# Altium schematic sheet High Voltage Section.SchDoc("High Voltage Section")
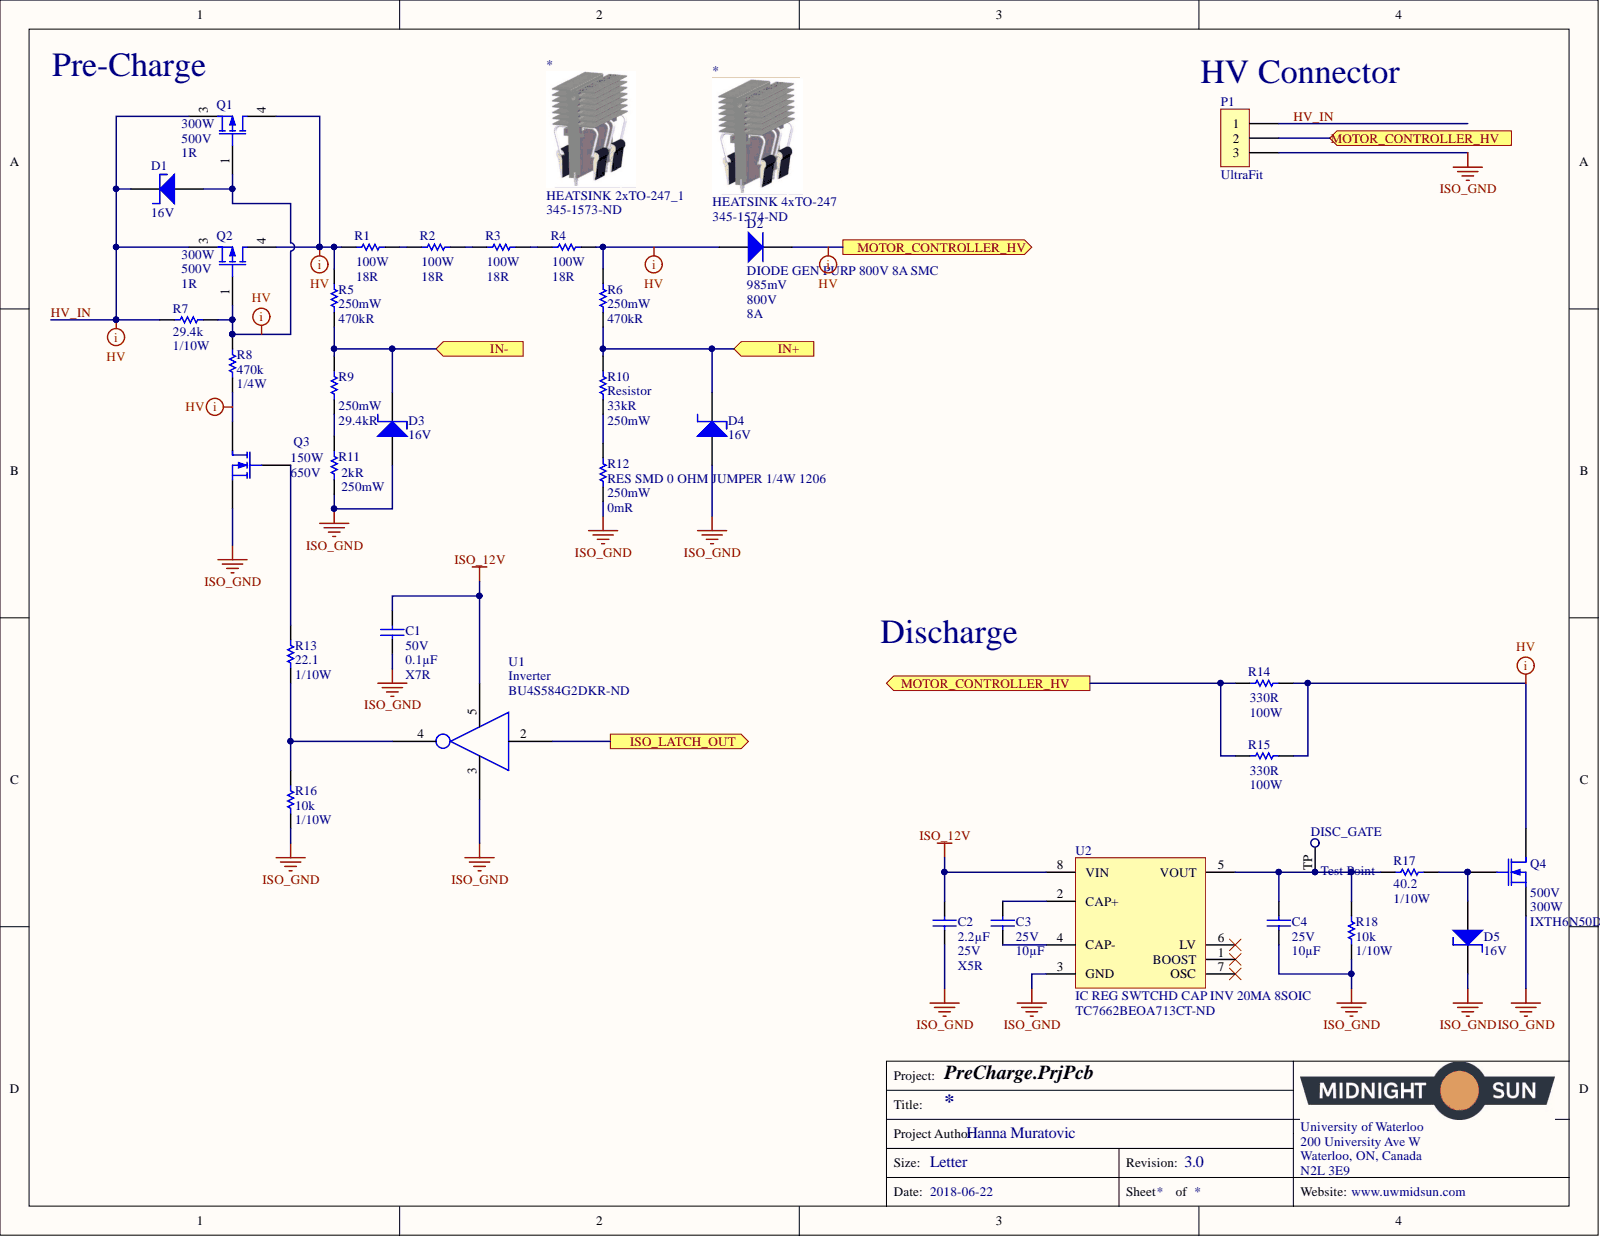
[diagram p0: High Voltage Section.SchDoc]

## Parts
*  HEATSINK 4xTO-247  [HEATSINK 4x TO-247]
*  HEATSINK 2xTO-247_1  [HEATSINK 2x TO-247]
C1  Voltage: 50V  Capacitance: 0.1µF  [CAP, 0603]  (hidden comment: CAP CER 0.1UF 50V 10% X7R 0603)
C2  Voltage: 25V  Temperature Coefficient: X5R  Capacitance: 2.2µF  [CAP, 0603]  (hidden comment: CAP CER 2.2UF 25V 10% X5R 0603)
C3  Voltage - Rated: 25V  Capacitance: 10µF  [CAP, 0805]  (hidden comment: CAP CER 10uF 25V 10% X5R 0805)
C4  Voltage - Rated: 25V  Capacitance: 10µF  [CAP, 0805]  (hidden comment: CAP CER 10uF 25V 10% X5R 0805)
D1  Voltage - Zener (Nom): 16V  [DO-214AA Diode]  (hidden comment: DIODE ZENER 16V 5W DO-214AA (SMB))
D2  DIODE GEN PURP 800V 8A SMC  Forward Voltage: 985mV  [DIODE, SMC]
D3  Voltage - Zener (Nom): 16V  [DO-214AA Diode]  (hidden comment: DIODE ZENER 16V 5W DO-214AA (SMB))
D4  Voltage - Zener (Nom): 16V  [DO-214AA Diode]  (hidden comment: DIODE ZENER 16V 5W DO-214AA (SMB))
D5  Voltage - Zener (Nom): 16V  [DO-214AA Diode]  (hidden comment: DIODE ZENER 16V 5W DO-214AA (SMB))
DISC_GATE  Test Point  [Test Point]  (no BOM)
P1  Series: UltraFit  (hidden comment: CONN 3POS ULTRA-FIT 0.138")
Q1  Rds On Max: 1R  Power Dissipation: 300W  Drain to Source Voltage (Vdss): 500V  [P-CH MOSFET 500V 10A 300W TO-263]  (hidden comment: IXTA10P50P)
Q2  Rds On Max: 1R  Power Dissipation: 300W  Drain to Source Voltage (Vdss): 500V  [P-CH MOSFET 500V 10A 300W TO-263]  (hidden comment: IXTA10P50P)
Q3  Max Power Dissipation: 150W  Drain to Source Voltage (Vdss): 650V  [P-CH MOSFET 500V 10A 300W TO-263]  (hidden comment: MOSFET N-CH 650V 8A X2 TO-263)
Q4  Max Power Dissipation: 300W  Drain to Source Voltage (Vdss): 500V  [N-CH Depletion Mode TO-247-3]  (hidden comment: MOSFET DEPLETION N-CH 500V 6A TO247)
R1  Power Rating: 100W  [RES TO-247-2]  (hidden comment: RES 18 OHM 100W 5% TO-247)
R2  Resistance: 18R  Power Rating: 100W  [RES TO-247-2]  (hidden comment: RES 18 OHM 100W 5% TO-247)
R3  Resistance: 18R  Power Rating: 100W  [RES TO-247-2]  (hidden comment: RES 18 OHM 100W 5% TO-247)
R4  Resistance: 18R  Power Rating: 100W  [RES TO-247-2]  (hidden comment: RES 18 OHM 100W 5% TO-247)
R5  Resistance: 470kR  Max Power Dissipation: 250mW  [RES 1206]  (hidden comment: RESISTOR)
R6  Resistance: 470kR  Max Power Dissipation: 250mW  [RES 1206]  (hidden comment: RESISTOR)
R7  Resistance (Ohms): 29.4k  Power (Watts): 1/10W  [RES, 0603]  (hidden comment: RES 29.4K OHM 1% 1/10W 0603)
R8  Resistance: 470k  Power: 1/4W  [RES, 0603]  (hidden comment: RES 470K OHM 1% 1/4W 0603)
R9  Resistor  Resistance: 29.4kR  Power Rating: 250mW  [RES 1206]
R10  Resistor  Resistance: 33kR  Power Rating: 250mW  [RES 1206]
R11  Resistance: 2kR  Power Rating: 250mW  [RES 1206]  (hidden comment: RES SMD 2K OHM 0.1% 1/4W 1206)
R12  RES SMD 0 OHM JUMPER 1/4W 1206  Resistance: 0mR  Power Rating: 250mW  [RES 1206]
R13  Resistance: 22.1  Power: 1/10W  [RES, 0603]  (hidden comment: RES 22.1 OHM 1% 1/10W 0603)
R14  Resistance: 330R  Power Rating: 100W  [RES TO-247-2]  (hidden comment: RES 330 OHM 100W 5% TO-247)
R15  Resistance: 330R  Power Rating: 100W  [RES TO-247-2]  (hidden comment: RES 330 OHM 100W 5% TO-247)
R16  Resistance: 10k  Power: 1/10W  [RES, 0603]  (hidden comment: RES 10K OHM 1% 1/10W 0603)
R17  Resistance: 40.2  Power: 1/10W  [RES, 0603]  (hidden comment: RES 40.2 OHM 0.5% 1/10W 0603)
R18  Resistance: 10k  Power: 1/10W  [RES, 0603]  (hidden comment: RES 10K OHM 1% 1/10W 0603)
U1  Inverter  Supplier Part Number 1: BU4S584G2DKR-ND  [SSOP5 - Inverter]
U2  IC REG SWTCHD CAP INV 20MA 8SOIC  Supplier Part Number 1: TC7662BEOA713CT-ND  [SOIC8]

## Ports
IN+, IN-, ISO_LATCH_OUT, MOTOR_CONTROLLER_HV

## Nets
ISO_12V: C1.1 C2.2 U1.5 U2.8(VIN)
ISO_GND: C1.2 C2.1 C4.2 D3.1 D4.1 D5.2 P1.3 Q3.3 Q4.3 R11.2 R12.2 R16.2 R18.2 U1.3 U2.3(GND)
HV_IN: D1.2 P1.1 Q1.3 Q2.3 R7.2
ISO_LATCH_OUT: U1.2 ; port ISO_LATCH_OUT
MOTOR_CONTROLLER_HV: D2.1 P1.2 R14.1 R15.1 ; port MOTOR_CONTROLLER_HV
NetC3_1: C3.1 U2.2(CAP+)
NetC3_2: C3.2 U2.4(CAP-)
NetC4_1: C4.1 DISC_GATE.TP R17.1 R18.1 U2.5(VOUT)
NetD1_1: D1.1 Q1.1 Q2.1 R7.1 R8.1
NetD2_2: D2.2 R4.2 R6.1
NetD3_2: D3.2 R5.2 R9.1
NetD4_2: D4.2 R6.2 R10.1
NetD5_1: D5.1 Q4.1 R17.2
NetQ1_4: Q1.4 Q2.4 R1.1 R5.1
NetQ3_1: Q3.1 R13.1
NetQ3_4: Q3.4 R8.2
NetQ4_2: Q4.2 R14.2 R15.2
NetR1_2: R1.2 R2.1
NetR2_2: R2.2 R3.1
NetR3_2: R3.2 R4.1
NetR9_2: R9.2 R11.1
NetR10_2: R10.2 R12.1
NetR13_2: R13.2 R16.1 U1.4

Not connected: U2 1(BOOST) 6(LV) 7(OSC)

## Library data of the parts
* = HEATSINK 4xTO-247: n Heat Sink TO-220, TO-247, TO-264 Aluminum Board Level, Vertical; Manufacturer Part Number: OMNI-UNI-30-50-D; Manufacturer: Wakefield; Supplier Part Number 1: 345-1574-ND; Supplier 1: Digi-Key; Stock 1: 465; Pricing 1: 1=4.09, 10=3.98, 25=3.8724, 50=3.6572, 100=3.4421, 250=3.22696, 500=3.11938, 1000=2.79669 (USD); Component Kind: Standard; Library Name: Schematic Diagrams.SchLib
* = HEATSINK 2xTO-247_1: Heat Sink TO-220, TO-247, TO-264 Aluminum Board Level, Vertical; Manufacturer Part Number: OMNI-UNI-30-50-D; Manufacturer: Wakefield; Supplier Part Number 1: 345-1573-ND; Supplier 1: Digi-Key; Stock 1: 499; Pricing 1: 1=2.8, 10=2.728, 25=2.6544, 50=2.5068, 100=2.3594, 250=2.21192, 500=2.13818, 1000=1.91698, 5000=1.88012 (USD); Component Kind: Standard; Library Name: Schematic Diagrams.SchLib
C1 = CAP CER 0.1UF 50V 10% X7R 0603: 0.10µF ±10% 50V Ceramic Capacitor X7R 0603 (1608 Metric); Manufacturer Part Number 1: 06035C104KAT2A; Manufacturer 1: AVX Corporation; Tolerance: ±10%; Thickness (Max): 0.035" (0.90mm); Temperature Coefficient: X7R; Supplier Part Number 1: 478-5052-1-ND; Supplier 1: Digi-Key; Size / Dimension: 0.063" L x 0.032" W (1.60mm x 0.81mm); Ratings: -; Part Status: Active; Packaging: Cut Tape (CT); Package / Case: 0603 (1608 Metric); Operating Temperature: -55°C ~ 125°C; Mounting Type: Surface Mount, MLCC; Lead Style: -; Lead Spacing: -; Height - Seated (Max): -; ComponentLink1URL: http://datasheets.avx.com/X7RDielectric.pdf; ComponentLink1Description: http://datasheets.avx.com/X7RDielectric.pdf; Component Kind: Standard; Library Name: Schematic Diagrams.SchLib
C2 = CAP CER 2.2UF 25V 10% X5R 0603: 2.2µF ±10% 25V Ceramic Capacitor X5R 0603 (1608 Metric); Manufacturer Part Number 1: GRM188R61E225KA12D; Manufacturer 1: Murata Electronics North America; Tolerance: ±10%; Thickness (Max): 0.035" (0.90mm); Supplier Part Number 1: 490-10731-1-ND; Supplier 1: Digi-Key; Size / Dimension: 0.063" L x 0.031" W (1.60mm x 0.80mm); Ratings: -; Part Status: Active; Packaging: Cut Tape (CT); Package / Case: 0603 (1608 Metric); Operating Temperature: -55°C ~ 85°C; Mounting Type: Surface Mount, MLCC; Lead Style: -; Lead Spacing: -; Height - Seated (Max): -; ComponentLink1URL: http://www.murata.com/~/media/webrenewal/support/library/catalog/products/capacitor/mlcc/c02e.ashx; ComponentLink1Description: http://www.murata.com/~/media/webrenewal/support/library/catalog/products/capacitor/mlcc/c02e.ashx; Component Kind: Standard; Library Name: Schematic Diagrams.SchLib
C3 C4 = CAP CER 10uF 25V 10% X5R 0805: 10µF ±10% 25V Ceramic Capacitor X5R 0805 (2012 Metric); Manufacturer Part Number: GRM21BR61E106KA73L; Manufacturer: Murata Electronics North America; Tolerance: ±10%; Thickness (Max): 0.053" (1.35mm); Temperature Coefficient: X5R; Supplier Part Number 1: 490-5523-1-ND; Supplier 1: Digi-Key; Stock 1: 1695157; Size / Dimension: 0.079" L x 0.049" W (2.00mm x 1.25mm); RoHS: RoHS Compliant; Ratings: -; Pricing 1: 1=0.3, 10=0.214, 100=0.1008, 500=0.07068, 1000=0.05851 (CAD); Part Status: Active; Packaging: Cut Tape (CT); Package / Case: 0805 (2012 Metric); Operating Temperature: -55°C ~ 85°C; Mounting Type: Surface Mount, MLCC; Lead Style: -; Lead Spacing: -; Height - Seated (Max): -; Features: -; ComponentLink1URL: http://search.murata.co.jp/Ceramy/image/img/A01X/G101/ENG/GRM21BR61E106KA73-01.pdf; ComponentLink1Description: http://search.murata.co.jp/Ceramy/image/img/A01X/G101/ENG/GRM21BR61E106KA73-01.pdf; Component Kind: Standard; Category: Capacitors; Applications: General Purpose; Library Name: Schematic Diagrams.SchLib
D1 D3-D5 = DIODE ZENER 16V 5W DO-214AA (SMB): Zener Diode 16V 5W ±5% Surface Mount DO-214AA (SMB); Manufacture Part Number: SMBJ5353B-TP; Manufacture: Micro Commercial Co; Voltage - Forward: 1.2V @ 1A; Impedence (Max): 2.5 Ohm; Supplier Part Number 1: SMBJ5353B-TPMSCT-ND; Supplier 1: Digi-Key; Power (Max): 5W; Component Kind: Standard; Library Name: Schematic Diagrams.SchLib
D2 = DIODE GEN PURP 800V 8A SMC: Manufacturer Part Number: S8KC-13; Manufacturer: Diodes; Peak Reverse Current: 10uA; Peak Non-Repetitive Surge Current: 200A; Element Configuration: Single; Width: 6.22mm; Weight: 0.007408oz; Supplier Part Number 1: S8KCDICT-ND; Supplier 1: Digi-Key; Stock 1: 38298; Reverse Voltage (DC): 800V; Radiation Hardening: No; Pricing 1: 1=0.68, 10=0.598, 100=0.4586, 500=0.36256, 1000=0.29005 (USD); Packaging: Tape and Reel; Package Quantity: 3000; Number of Pins: 2; Mount: Surface Mount; Min Operating Temperature: -65°C; Max Surge Current: 200A; Max Reverse Voltage (DC): 800V; Max Repetitive Reverse Voltage (Vrrm): 800V; Max Operating Temperature: 150°C; Max Forward Surge Current (Ifsm): 200A; Length: 7.11mm; Lead Free: Lead Free; Height: 2.42mm; Forward Current: 8A; Contact Plating: Tin,Matte; ComponentLink4URL: https://datasheet.ciiva.com/4453/ds31117-63855-4453947.pdf; ComponentLink4Description: https://datasheet.ciiva.com/4453/ds31117-63855-4453947.pdf; ComponentLink3URL: https://datasheet.ciiva.com/914/ds31117-914779.pdf; ComponentLink3Description: https://datasheet.ciiva.com/914/ds31117-914779.pdf; ComponentLink2URL: https://datasheet.ciiva.com/16388/ds31117-16388346.pdf; ComponentLink2Description: https://datasheet.ciiva.com/16388/ds31117-16388346.pdf; ComponentLink1URL: https://datasheet.ciiva.com/15144/getdatasheetpartid-61102-15144323.pdf; ComponentLink1Description: https://datasheet.ciiva.com/15144/getdatasheetpartid-61102-15144323.pdf; Component Kind: Standard; Case/Package: SMC; Average Rectified Current: 8A; Library Name: Schematic Diagrams.SchLib
P1 = CONN 3POS ULTRA-FIT 0.138": 3 Positions Header Connector 0.138" (3.50mm) Through Hole Tin; Manufacturer Part Number 1: 1722871103; Manufacturer 1: Molex, LLC; Voltage: 400V; Style: Board to Cable/Wire; Shrouding: Shrouded - 4 Wall; Number of Positions Loaded: All; Number of Positions: 3; Material Flammability Rating: UL94 V-0; Mated Stacking Heights: 26.99mm; Contact Length - Post: 0.126" (3.20mm); Termination: Solder; Supplier Part Number 1: WM11702-ND; Supplier 1: Digi-Key; Row Spacing - Mating: -; Pitch - Mating: 0.138" (3.50mm); Part Status: Active; Packaging: Tray; Overall Contact Length: -; Operating Temperature: -40°C ~ 105°C; Number of Rows: 1; Mounting Type: Through Hole; Insulation Material: Liquid Crystal Polymer (LCP); Insulation Height: 0.465" (11.80mm); Insulation Color: Black; Ingress Protection: -; Fastening Type: Latch Holder; Current Rating: -; Contact Type: Male Pin; Contact Shape: Square; Contact Material: Copper Alloy; Contact Length - Mating: -; Contact Finish Thickness - Post: 60µin (1.52µm); Contact Finish Thickness - Mating: 60µin (1.52µm); Contact Finish - Post: Tin; Contact Finish - Mating: Tin; Connector Type: Header; ComponentLink1URL: http://www.molex.com/pdm_docs/sd/1722871103_sd.pdf; ComponentLink1Description: http://www.molex.com/pdm_docs/sd/1722871103_sd.pdf; Component Kind: Standard; Library Name: Schematic Diagrams.SchLib
Q1 Q2 = MOSFET P-CH 500V 10A 300W TO-263: P-Channel 500V 10A (Tc) 300W (Tc) Surface Mount TO-263 (IXTA); Manufacturer: IXYS; Turn-Off Delay Time: 52ns; Rise Time: 28ns; Number of Elements: 1; Input Capacitance: 2.84nF; Gate to Source Voltage (Vgs): 20V; Fall Time: 44ns; Element Configuration: Single; Drain to Source Breakdown Voltage: -500V; Supplier Part Number 1: IXTA10P50P-ND; Supplier 1: Digi-Key; Stock 1: 2142; Radiation Hardening: No; Pricing 1: 1=5.96, 10=5.319, 100=4.3614, 500=3.53166, 1000=2.9785 (USD); Packaging: Rail/Tube; Package Quantity: 50; On-State Resistance: 1R; Mount: Surface Mount; Min Operating Temperature: -55°C; Max Power Dissipation: 300W; Max Operating Temperature: 150°C; Manufacturer Part Number: IXTA10P50P; Lead Free: Lead Free; Drain to Source Resistance: 1R; Continuous Drain Current (ID): 10A; ComponentLink1URL: https://datasheet.ciiva.com/15203/getdatasheetpartid-371166-15203275.pdf; ComponentLink1Description: https://datasheet.ciiva.com/15203/getdatasheetpartid-371166-15203275.pdf; Component Kind: Standard; Case/Package: TO-263; Library Name: Schematic Diagrams.SchLib
Q3 = MOSFET N-CH 650V 8A X2 TO-263: N-Channel 650V 8A (Tc) 150W (Tc) Surface Mount TO-263; Manufacturer Part Number: IXTA8N65X2; Manufacturer: IXYS; Rds On Max: 500mR; Input Capacitance: 800pF; Supplier Part Number 1: IXTA8N65X2-ND; Supplier 1: Digi-Key; Stock 1: 69; Pricing 1: 1=2.85, 10=2.576, 100=2.07, 500=1.61, 1000=1.334 (USD); Packaging: Rail/Tube; Mount: Surface Mount; Min Operating Temperature: -55°C; Max Operating Temperature: 150°C; Continuous Drain Current (ID): 8A; ComponentLink2URL: http://ixapps.ixys.com/DataSheet/DS100649B(IXTY-TA-TP8N65X2).pdf; ComponentLink2Description: http://ixapps.ixys.com/DataSheet/DS100649B(IXTY-TA-TP8N65X2).pdf; ComponentLink1URL: http://www.mouser.com/ds/2/205/DS100649B(IXTY-TA-TP8N65X2)-1132259.pdf; ComponentLink1Description: http://www.mouser.com/ds/2/205/DS100649B(IXTY-TA-TP8N65X2)-1132259.pdf; Component Kind: Standard; Case/Package: TO-263-3; Library Name: Schematic Diagrams.SchLib
Q4 = MOSFET DEPLETION N-CH 500V 6A TO247: N-Channel 500V 6A (Tc) 300W (Tc) Through Hole TO-247 (IXTH); Manufacturer Part Number: IXTH6N50D2; Manufacturer: IXYS; Rds On Max: 500mR; Input Capacitance: 2.8nF; Supplier Part Number 1: IXTH6N50D2-ND; Supplier 1: Digi-Key; Stock 1: 79; Pricing 1: 1=7.25, 10=6.521, 100=5.3613, 500=4.4919, 1000=3.9123 (USD); Packaging: Rail/Tube; Package Quantity: 30; Mount: Through Hole; Continuous Drain Current (ID): 6A; ComponentLink2URL: https://datasheet.ciiva.com/26790/ixth6n50d2-26790808.pdf; ComponentLink2Description: https://datasheet.ciiva.com/26790/ixth6n50d2-26790808.pdf; ComponentLink1URL: https://datasheet.ciiva.com/949/ds100177bixta-tp-th6n50d2-949628.pdf; ComponentLink1Description: https://datasheet.ciiva.com/949/ds100177bixta-tp-th6n50d2-949628.pdf; Component Kind: Standard; Case/Package: TO-247-3; Library Name: Schematic Diagrams.SchLib
R1 = RES 18 OHM 100W 5% TO-247: 18 Ohms ±5% 100W Through Hole Resistor TO-247-2 Moisture Resistant, Non-Inductive Thick Film; Manufacturer Part Number: AP10118RJ; Manufacturer: Ohmite; Voltage Rating (DC): 700V; Temperature Coefficient: 100ppm/°C; Resistance: 18R; Width: 0.195inch; Voltage Rating: 700V; Tolerance: 5%; Supplier Part Number 1: AP101 18R J-ND; Supplier 1: Digi-Key; Stock 1: 0; REACH SVHC: Unknown; Packaging: Tray; Number of Terminations: 2; Min Operating Temperature: -65°C; Max Power Dissipation: 100W; Max Operating Temperature: 175°C; Length: 0.62inch; Height: 0.825inch; Composition: Thick Film; ComponentLink3URL: http://www.ohmite.com/cat/acl_ap101.pdf; ComponentLink3Description: http://www.ohmite.com/cat/acl_ap101.pdf; ComponentLink2URL: http://www.mouser.com/ds/2/303/acl_ap101-773331.pdf; ComponentLink2Description: http://www.mouser.com/ds/2/303/acl_ap101-773331.pdf; ComponentLink1URL: http://www.farnell.com/datasheets/2015590.pdf; ComponentLink1Description: http://www.farnell.com/datasheets/2015590.pdf; Component Kind: Standard; Case/Package: TO-247-2; Library Name: Schematic Diagrams.SchLib
R2-R4 = RES 18 OHM 100W 5% TO-247: 18 Ohms ±5% 100W Through Hole Resistor TO-247-2 Moisture Resistant, Non-Inductive Thick Film; Manufacturer Part Number: AP10118RJ; Manufacturer: Ohmite; Voltage Rating (DC): 700V; Temperature Coefficient: 100ppm/°C; Width: 0.195inch; Voltage Rating: 700V; Tolerance: 5%; Supplier Part Number 1: AP101 18R J-ND; Supplier 1: Digi-Key; Stock 1: 0; REACH SVHC: Unknown; Packaging: Tray; Number of Terminations: 2; Min Operating Temperature: -65°C; Max Power Dissipation: 100W; Max Operating Temperature: 175°C; Length: 0.62inch; Height: 0.825inch; Composition: Thick Film; ComponentLink3URL: http://www.ohmite.com/cat/acl_ap101.pdf; ComponentLink3Description: http://www.ohmite.com/cat/acl_ap101.pdf; ComponentLink2URL: http://www.mouser.com/ds/2/303/acl_ap101-773331.pdf; ComponentLink2Description: http://www.mouser.com/ds/2/303/acl_ap101-773331.pdf; ComponentLink1URL: http://www.farnell.com/datasheets/2015590.pdf; ComponentLink1Description: http://www.farnell.com/datasheets/2015590.pdf; Component Kind: Standard; Case/Package: TO-247-2; Library Name: Schematic Diagrams.SchLib
R5 R6 = RES SMD 470K OHM 0.1% 1/4W 1206: 470 kOhms ±0.1% 0.25W, 1/4W Chip Resistor 1206 (3216 Metric) Anti-Sulfur, Automotive AEC-Q200 Thin Film; Manufacturer Part Number: RG3216P-4703-B-T1; Manufacturer: Susumu; Voltage Rating (DC): 200V; Voltage Rating: 150V; Temperature Coefficient: 25ppm/°C; Power Rating: 125mW; Width: 0.063inch; Tolerance: 0.1%; Termination: SMD/SMT; Supplier Part Number 1: RG32P470KBDKR-ND; Supplier 1: Digi-Key; Stock 1: 2986; Pricing 1: 1=0.66, 10=0.474, 100=0.2462 (USD); Packaging: Tape and Reel; Package Quantity: 1; Number of Terminations: 2; Number of Pins: 2; Min Operating Temperature: -55°C; Max Operating Temperature: 155°C; Length: 0.126inch; Lead Free: Lead Free; Height: 0.02inch; Composition: Thin Film; ComponentLink4URL: https://datasheet.ciiva.com/12760/rg-rm-rgh-datasheet-12760397.pdf; ComponentLink4Description: https://datasheet.ciiva.com/12760/rg-rm-rgh-datasheet-12760397.pdf; ComponentLink3URL: https://datasheet.ciiva.com/2490/rg-rm-rgh-datasheet-2490490.pdf; ComponentLink3Description: https://datasheet.ciiva.com/2490/rg-rm-rgh-datasheet-2490490.pdf; ComponentLink2URL: https://datasheet.ciiva.com/2490/rg-rm-rgh-datasheet-2490489.pdf; ComponentLink2Description: https://datasheet.ciiva.com/2490/rg-rm-rgh-datasheet-2490489.pdf; ComponentLink1URL: https://datasheet.ciiva.com/2490/rg-rm-rgh-datasheet-2490488.pdf; ComponentLink1Description: https://datasheet.ciiva.com/2490/rg-rm-rgh-datasheet-2490488.pdf; Component Kind: Standard; Case Code (Metric): 3216; Case Code (Imperial): 1206; Case/Package: 1206; Library Name: Schematic Diagrams.SchLib
R7 = RES 29.4K OHM 1% 1/10W 0603: 29.4k Ohm ±1% 0.1W, 1/10W Chip Resistor 0603 (1608 Metric) Moisture Resistant Thick Film; Manufacturer Part Number 1: RC0603FR-0729K4L; Manufacturer 1: Yageo; Temperature Coefficient: ±100ppm/°C; Description 1: RES SMD 29.4K OHM 1% 1/10W 0603; Tolerance: ±1%; Supplier Part Number 1: 311-29.4KHRCT-ND; Supplier Device Package: 0603; Supplier 1: Digi-Key; Stock 1: 76118; Size / Dimension: 0.063" L x 0.031" W (1.60mm x 0.80mm); RoHS: RoHS Compliant; Pricing 1: 1=0.16, 10=0.022, 100=0.0089, 1000=0.00402, 2500=0.00349 (CAD); Part Status: Active; Packaging: Cut Tape (CT); Package / Case: 0603 (1608 Metric); Operating Temperature: -55°C ~ 155°C; Height - Seated (Max): 0.022" (0.55mm); Features: Moisture Resistant; Failure Rate: -; Composition: Thick Film; ComponentLink1URL: http://www.yageo.com/documents/recent/PYu-RC_Group_51_RoHS_L_7.pdf; ComponentLink1Description: http://www.yageo.com/documents/recent/PYu-RC_Group_51_RoHS_L_7.pdf; Component Kind: Standard; Category: Resistors; Library Name: Schematic Diagrams.SchLib
R8 = RES 470K OHM 1% 1/4W 0603: RES SMD 470K OHM 1% 1/4W 0603; Manufacturer Part Number 1: ERJ-PA3F4703V; Manufacturer 1: Panasonic Electronic Components; Temperature Coefficient: ±100ppm/°C; Tolerance: ±1%; Supplier Part Number 1: P470KBYCT-ND; Supplier Device Package: 0603; Supplier 1: Digi-Key; Size / Dimension: 0.063" L x 0.031" W (1.60mm x 0.80mm); Part Status: Active; Packaging: Cut Tape (CT); Package / Case: 0603 (1608 Metric); Operating Temperature: -55°C ~ 155°C; Height - Seated (Max): 0.022" (0.55mm); Failure Rate: -; Composition: Thick Film; ComponentLink1URL: https://industrial.panasonic.com/cdbs/www-data/pdf/RDO0000/AOA0000C291.pdf; ComponentLink1Description: https://industrial.panasonic.com/cdbs/www-data/pdf/RDO0000/AOA0000C291.pdf; Component Kind: Standard; Library Name: Schematic Diagrams.SchLib
R9 = RES SMD 29.4K OHM 1% 1/4W 1206: 29.4 kOhms ±1% 0.25W, 1/4W Chip Resistor 1206 (3216 Metric) Automotive AEC-Q200 Thick Film; Manufacturer Part Number: ERJ-8ENF2942V; Manufacturer: Panasonic; Voltage Rating (DC): 200V; Temperature Coefficient: 100ppm/°C; Width: 0.063inch; Weight: 0.000571oz; Voltage Rating: 200V; Tolerance: 1%; Termination: SMD/SMT; Supplier Part Number 1: P29.4KFCT-ND; Supplier 1: Digi-Key; Stock 1: 24502; REACH SVHC: No SVHC; Pricing 1: 1=0.11, 10=0.097, 100=0.0373, 1000=0.01641, 2500=0.01417 (USD); Packaging: Tape and Reel; Package Quantity: 1; Number of Terminations: 2; Mount: Surface Mount; Min Operating Temperature: -55°C; Military Standard: Not; Max Power Dissipation: 250mW; Max Operating Temperature: 155°C; Length: 3.2mm; Height: 0.028inch; Depth: 1.6mm; Contact Plating: Tin; Composition: Thick Film; ComponentLink4URL: https://datasheet.ciiva.com/9191/aoa0000ce2-9191007.pdf; ComponentLink4Description: https://datasheet.ciiva.com/9191/aoa0000ce2-9191007.pdf; ComponentLink3URL: https://datasheet.ciiva.com/6159/426256-6159628.pdf; ComponentLink3Description: https://datasheet.ciiva.com/6159/426256-6159628.pdf; ComponentLink2URL: https://datasheet.ciiva.com/24126/aoa0000ce2-24126731.pdf; ComponentLink2Description: https://datasheet.ciiva.com/24126/aoa0000ce2-24126731.pdf; ComponentLink1URL: https://datasheet.ciiva.com/7861/426256-7861912.pdf; ComponentLink1Description: https://datasheet.ciiva.com/7861/426256-7861912.pdf; Component Kind: Standard; Case Code (Metric): 3216; Case Code (Imperial): 1206; Case/Package: 1206; Library Name: Schematic Diagrams.SchLib
R10 = RES SMD 33K OHM 0.1% 1/4W 1206: 33 kOhms ±0.1% 0.25W, 1/4W Chip Resistor 1206 (3216 Metric) Thin Film; Manufacturer Part Number: RT1206BRD0733KL; Manufacturer: Yageo; Voltage Rating (DC): 200V; Temperature Coefficient: 25ppm/°C; Width: 0.063inch; Voltage Rating: 200V; Tolerance: 0.1%; Termination: SMD/SMT; Supplier Part Number 1: YAG2038CT-ND; Supplier 1: Digi-Key; Stock 1: 13446; Radiation Hardening: No; Pricing 1: 1=0.68, 10=0.523, 100=0.22, 1000=0.12137, 2500=0.11378 (USD); Packaging: Tape and Reel; Package Quantity: 5000; Number of Terminations: 2; Min Operating Temperature: -55°C; Military Standard: Not; Max Power Dissipation: 250mW; Max Operating Temperature: 125°C; Length: 0.122inch; Lead Free: Lead Free; Height: 0.026inch; Depth: 1.6mm; Contact Plating: Tin,Matte; Composition: Thin Film; ComponentLink2URL: https://datasheet.ciiva.com/46634/pyu-rt-1-to-0-05-rohs-l-5-46634965.pdf; ComponentLink2Description: https://datasheet.ciiva.com/46634/pyu-rt-1-to-0-05-rohs-l-5-46634965.pdf; ComponentLink1URL: https://datasheet.ciiva.com/2587/pyu-rt-1-to-0-05-rohs-l-4-2587636.pdf; ComponentLink1Description: https://datasheet.ciiva.com/2587/pyu-rt-1-to-0-05-rohs-l-4-2587636.pdf; Component Kind: Standard; Case Code (Metric): 3216; Case Code (Imperial): 1206; Case/Package: 1206; Library Name: Schematic Diagrams.SchLib
R11 = RES SMD 2K OHM 0.1% 1/4W 1206: 2 kOhms ±0.1% 0.25W, 1/4W Chip Resistor 1206 (3216 Metric) Automotive AEC-Q200 Thin Film; Manufacturer Part Number: ERA-8AEB202V; Manufacturer: Panasonic; Voltage Rating (DC): 150V; Temperature Coefficient: 25ppm/°C; Width: 0.063inch; Weight: 0.000571oz; Voltage Rating: 150V; Tolerance: 0.1%; Termination: SMD/SMT; Supplier Part Number 1: P2.0KBCCT-ND; Supplier 1: Digi-Key; Stock 1: 43293; REACH SVHC: No SVHC; Radiation Hardening: No; Pricing 1: 1=0.66, 10=0.474, 100=0.2465, 1000=0.13276, 2500=0.13095 (USD); Packaging: Tape and Reel; Package Quantity: 5000; Number of Terminations: 2; Number of Pins: 2; Mount: Surface Mount; Min Operating Temperature: -55°C; Military Standard: Not; Max Power Dissipation: 250mW; Max Operating Temperature: 155°C; Length: 3.2mm; Lead Free: Lead Free; Height: 0.6mm; Depth: 1.6mm; Contact Plating: Tin; Composition: Metal Film,Thin Film; ComponentLink5URL: https://datasheet.ciiva.com/9197/aoa0000ce26-9197582.pdf; ComponentLink5Description: https://datasheet.ciiva.com/9197/aoa0000ce26-9197582.pdf; ComponentLink4URL: https://datasheet.ciiva.com/17214/aoa0000ce26-17214381.pdf; ComponentLink4Description: https://datasheet.ciiva.com/17214/aoa0000ce26-17214381.pdf; ComponentLink3URL: https://datasheet.ciiva.com/6082/391390-6082060.pdf; ComponentLink3Description: https://datasheet.ciiva.com/6082/391390-6082060.pdf; ComponentLink2URL: https://datasheet.ciiva.com/48529/era-eng-tds-48529591.pdf; ComponentLink2Description: https://datasheet.ciiva.com/48529/era-eng-tds-48529591.pdf; ComponentLink1URL: https://datasheet.ciiva.com/43458/391390-43458070.pdf; ComponentLink1Description: https://datasheet.ciiva.com/43458/391390-43458070.pdf; Component Kind: Standard; Case Code (Metric): 3216; Case Code (Imperial): 1206; Case/Package: 1206; Library Name: Schematic Diagrams.SchLib
R12 = RES SMD 0 OHM JUMPER 1/4W 1206: 0 Ohms Jumper 0.25W, 1/4W Chip Resistor 1206 (3216 Metric) Automotive AEC-Q200 Thick Film; Manufacturer Part Number: CRCW12060000Z0EA; Manufacturer: Vishay; Voltage Rating (DC): 200V; Tolerance: 1%; Temperature Coefficient: 100ppm/°C; Width: 1.6mm; Weight: 0.000353oz; Voltage Rating: 200V; Termination: SMD/SMT; Supplier Part Number 1: 541-0.0ECT-ND; Supplier 1: Digi-Key; Stock 1: 0; REACH SVHC: Unknown; Radiation Hardening: No; Pricing 1: 1=0.1, 10=0.064, 25=0.046, 50=0.0352, 100=0.0259, 250=0.01976, 500=0.0158, 1000=0.01163 (USD); Packaging: Tape and Reel; Package Quantity: 5000; Mount: Surface Mount; Min Operating Temperature: -55°C; Military Standard: Not; Max Operating Temperature: 155°C; Length: 3.2mm; Lead Free: Lead Free; Height: 0.55mm; Depth: 1.6mm; Contact Plating: Tin; Composition: Thick Film; ComponentLink7URL: https://datasheet.ciiva.com/16782/70201119-16782555.pdf; ComponentLink7Description: https://datasheet.ciiva.com/16782/70201119-16782555.pdf; ComponentLink6URL: https://datasheet.ciiva.com/11809/0900766b80d98b5e-11809211.pdf; ComponentLink6Description: https://datasheet.ciiva.com/11809/0900766b80d98b5e-11809211.pdf; ComponentLink5URL: https://datasheet.ciiva.com/7841/1697337-7841706.pdf; ComponentLink5Description: https://datasheet.ciiva.com/7841/1697337-7841706.pdf; ComponentLink4URL: https://datasheet.ciiva.com/5549/dcrcwe3-109170-5549528.pdf; ComponentLink4Description: https://datasheet.ciiva.com/5549/dcrcwe3-109170-5549528.pdf; ComponentLink3URL: https://datasheet.ciiva.com/16364/dcrcwe3-16364998.pdf; ComponentLink3Description: https://datasheet.ciiva.com/16364/dcrcwe3-16364998.pdf; ComponentLink2URL: https://datasheet.ciiva.com/7841/1720486-7841862.pdf; ComponentLink2Description: https://datasheet.ciiva.com/7841/1720486-7841862.pdf; ComponentLink1URL: https://datasheet.ciiva.com/16720/70201119-16720117.pdf; ComponentLink1Description: https://datasheet.ciiva.com/16720/70201119-16720117.pdf; Component Kind: Standard; Case Code (Metric): 3216; Case Code (Imperial): 1206; Case/Package: 1206; Library Name: Schematic Diagrams.SchLib
R13 = RES 22.1 OHM 1% 1/10W 0603: 22.1 Ohm ±1% 0.1W, 1/10W Chip Resistor 0603 (1608 Metric) Moisture Resistant Thick Film; Manufacturer Part Number 1: RC0603FR-0722R1L; Manufacturer 1: Yageo; Temperature Coefficient: ±100ppm/°C; Tolerance: ±1%; Supplier Part Number 1: 311-22.1HRCT-ND; Supplier Device Package: 0603; Supplier 1: Digi-Key; Size / Dimension: 0.063" L x 0.031" W (1.60mm x 0.80mm); Part Status: Active; Packaging: Cut Tape (CT); Package / Case: 0603 (1608 Metric); Operating Temperature: -55°C ~ 155°C; Height - Seated (Max): 0.022" (0.55mm); Failure Rate: -; Composition: Thick Film; ComponentLink1URL: http://www.yageo.com/documents/recent/PYu-RC_Group_51_RoHS_L_7.pdf; ComponentLink1Description: http://www.yageo.com/documents/recent/PYu-RC_Group_51_RoHS_L_7.pdf; Component Kind: Standard; Library Name: Schematic Diagrams.SchLib
R14 R15 = RES 330 OHM 100W 5% TO-247: Manufacturer Part Number: AP101330RJ; Manufacturer: Ohmite; Voltage Rating (DC): 700V; Temperature Coefficient: 100ppm/°C; Width: 0.195inch; Voltage Rating: 700V; Tolerance: 5%; Supplier Part Number 1: AP101 330R J-ND; Supplier 1: Digi-Key; Stock 1: 0; Packaging: Tray; Number of Terminations: 2; Min Operating Temperature: -65°C; Max Power Dissipation: 100W; Max Operating Temperature: 175°C; Length: 0.62inch; Height: 0.825inch; Composition: Thick Film; ComponentLink3URL: http://www.ohmite.com/cat/acl_ap101.pdf; ComponentLink3Description: http://www.ohmite.com/cat/acl_ap101.pdf; ComponentLink2URL: http://www.farnell.com/datasheets/2015590.pdf; ComponentLink2Description: http://www.farnell.com/datasheets/2015590.pdf; ComponentLink1URL: http://www.mouser.com/ds/2/303/acl_ap101-773331.pdf; ComponentLink1Description: http://www.mouser.com/ds/2/303/acl_ap101-773331.pdf; Component Kind: Standard; Case/Package: TO-247-2; Library Name: Schematic Diagrams.SchLib
R16 R18 = RES 10K OHM 1% 1/10W 0603: RES SMD 10K OHM 1% 1/10W 0603; Manufacturer Part Number 1: RC0603FR-0710KL; Manufacturer 1: Yageo; Temperature Coefficient: ±100ppm/°C; Tolerance: ±1%; Supplier Part Number 1: 311-10.0KHRCT-ND; Supplier Device Package: 0603; Supplier 1: Digi-Key; Size / Dimension: 0.063" L x 0.031" W (1.60mm x 0.80mm); Part Status: Active; Packaging: Cut Tape (CT); Package / Case: 0603 (1608 Metric); Operating Temperature: -55°C ~ 155°C; Height - Seated (Max): 0.022" (0.55mm); Failure Rate: -; Composition: Thick Film; ComponentLink1URL: http://www.yageo.com/documents/recent/PYu-RC_Group_51_RoHS_L_7.pdf; ComponentLink1Description: http://www.yageo.com/documents/recent/PYu-RC_Group_51_RoHS_L_7.pdf; Component Kind: Standard; Library Name: Schematic Diagrams.SchLib
R17 = RES 40.2 OHM 0.5% 1/10W 0603: RES SMD 40.2 OHM 0.5% 1/10W 0603; Manufacturer Part Number 1: RT0603DRE0740R2L; Manufacturer 1: Yageo; Temperature Coefficient: ±50ppm/°C; Tolerance: ±0.5%; Supplier Part Number 1: 311-2576-1-ND; Supplier Device Package: 0603; Supplier 1: Digi-Key; Size / Dimension: 0.063" L x 0.031" W (1.60mm x 0.80mm); Part Status: Active; Packaging: Cut Tape (CT); Package / Case: 0603 (1608 Metric); Operating Temperature: -55°C ~ 155°C; Height - Seated (Max): 0.022" (0.55mm); Failure Rate: -; Composition: Thin Film; ComponentLink1URL: http://www.yageo.com/documents/recent/PYu-RT_1-to-0.01_RoHS_L_7.pdf; ComponentLink1Description: http://www.yageo.com/documents/recent/PYu-RT_1-to-0.01_RoHS_L_7.pdf; Component Kind: Standard; Library Name: Schematic Diagrams.SchLib
U1 = IC INVERTER SCHMITT 1CH 5SSOP: Inverter IC 1 Channel Schmitt Trigger 5-SSOP; Manufacturer Part Number: BU4S584G2-TR; Manufacturer: Rohm; Turn-On Delay Time: 50ns; Quiescent Current: 1uA; Number of Inputs: 1; Min Supply Voltage: 3V; Max Supply Voltage: 16V; Low Level Output Current: 3.4mA; Logic Function: Schmitt Trigger,Buffer,Inverter; Supplier 1: Digi-Key; Stock 1: 28906; REACH SVHC: Unknown; Radiation Hardening: No; Propagation Delay: 50ns; Pricing 1: 1=0.68, 10=0.571, 25=0.4996, 100=0.4283, 250=0.37116, 500=0.31406, 1000=0.24269 (USD); Packaging: Tape and Reel; Package Quantity: 3000; Number of Pins: 5; Number of Gates: 1; Number of Elements: 1; Number of Circuits: 1; Mount: Surface Mount; Min Operating Temperature: -40°C; Max Operating Temperature: 85°C; Lead Free: Lead Free; High Level Output Current: 3.4mA; Contact Plating: Copper,Tin; ComponentLink8URL: https://datasheet.ciiva.com/26326/140506-da-01-en-ic-bu4s584g2-tr-ssop5-26326013.pdf; ComponentLink8Description: https://datasheet.ciiva.com/26326/140506-da-01-en-ic-bu4s584g2-tr-ssop5-26326013.pdf; ComponentLink7URL: https://datasheet.ciiva.com/26865/bu4s584g2-tr-26865344.pdf; ComponentLink7Description: https://datasheet.ciiva.com/26865/bu4s584g2-tr-26865344.pdf; ComponentLink6URL: https://datasheet.ciiva.com/1863/bu4s01g2-e-1863487.pdf; ComponentLink6Description: https://datasheet.ciiva.com/1863/bu4s01g2-e-1863487.pdf; ComponentLink5URL: https://datasheet.ciiva.com/15089/getdatasheetpartid-451247-15089726.pdf; ComponentLink5Description: https://datasheet.ciiva.com/15089/getdatasheetpartid-451247-15089726.pdf; ComponentLink4URL: https://datasheet.ciiva.com/1863/bu4s01g2-e-1863486.pdf; ComponentLink4Description: https://datasheet.ciiva.com/1863/bu4s01g2-e-1863486.pdf; ComponentLink3URL: https://datasheet.ciiva.com/1863/bu4s01g2-e-1863485.pdf; ComponentLink3Description: https://datasheet.ciiva.com/1863/bu4s01g2-e-1863485.pdf; ComponentLink2URL: https://datasheet.ciiva.com/8247/bu4s01g2-e-8247480.pdf; ComponentLink2Description: https://datasheet.ciiva.com/8247/bu4s01g2-e-8247480.pdf; ComponentLink1URL: https://datasheet.ciiva.com/16203/bu4s584g2-e-16203782.pdf; ComponentLink1Description: https://datasheet.ciiva.com/16203/bu4s584g2-e-16203782.pdf; Component Kind: Standard; Case/Package: SSOP; Library Name: Schematic Diagrams.SchLib
U2 = IC REG SWTCHD CAP INV 20MA 8SOIC: Charge Pump Switching Regulator IC Positive or Negative Fixed -Vin, 2Vin 1 Output 20mA 8-SOIC (0.154", 3.90mm Width); Manufacturer Part Number: TC7662BEOA713; Manufacturer: Microchip; Synchronous Rectifier: No; Switching Frequency: 35kHz; Output Voltage: -15V; Output Power: 470mW; Number of Outputs: 1; Min Supply Voltage: 1.5V; Max Supply Voltage: 15V; Logic Function: Inverting; Width: 3.99mm; Weight: 0.006596oz; Topology: Charge Pump; Supplier 1: Digi-Key; Stock 1: 59; Radiation Hardening: No; Pricing 1: 1=2.29, 25=1.9056, 100=1.7304 (USD); Packaging: Tape and Reel; Package Quantity: 1; Output Current: 20mA; Number of Pins: 8; Mount: Surface Mount; Min Operating Temperature: -40°C; Max Output Voltage: -15V; Max Output Current: 20mA; Max Operating Temperature: 85°C; Max Input Voltage: 15V; Length: 5mm; Lead Free: Lead Free; Height: 1.5mm; ComponentLink6URL: https://datasheet.ciiva.com/4875/21469a-4875151.pdf; ComponentLink6Description: https://datasheet.ciiva.com/4875/21469a-4875151.pdf; ComponentLink5URL: https://datasheet.ciiva.com/18553/filehandler-aspxddocname-en026002-18553038.pdf; ComponentLink5Description: https://datasheet.ciiva.com/18553/filehandler-aspxddocname-en026002-18553038.pdf; ComponentLink4URL: https://datasheet.ciiva.com/3216/cn-21469a-3216331.pdf; ComponentLink4Description: https://datasheet.ciiva.com/3216/cn-21469a-3216331.pdf; ComponentLink3URL: https://datasheet.ciiva.com/16295/filehandler-aspxddocname-en011347-16295442.pdf; ComponentLink3Description: https://datasheet.ciiva.com/16295/filehandler-aspxddocname-en011347-16295442.pdf; ComponentLink2URL: https://datasheet.ciiva.com/2024/filehandler-aspxddocname-en026002-2024369.pdf; ComponentLink2Description: https://datasheet.ciiva.com/2024/filehandler-aspxddocname-en026002-2024369.pdf; ComponentLink1URL: https://datasheet.ciiva.com/2024/21469a-2024368.pdf; ComponentLink1Description: https://datasheet.ciiva.com/2024/21469a-2024368.pdf; Component Kind: Standard; Case/Package: SOIC; Library Name: Schematic Diagrams.SchLib
DISC_GATE = Test Point: Library Name: Schematic Diagrams.SchLib
